# Altium schematic sheet Schematic.SchDoc
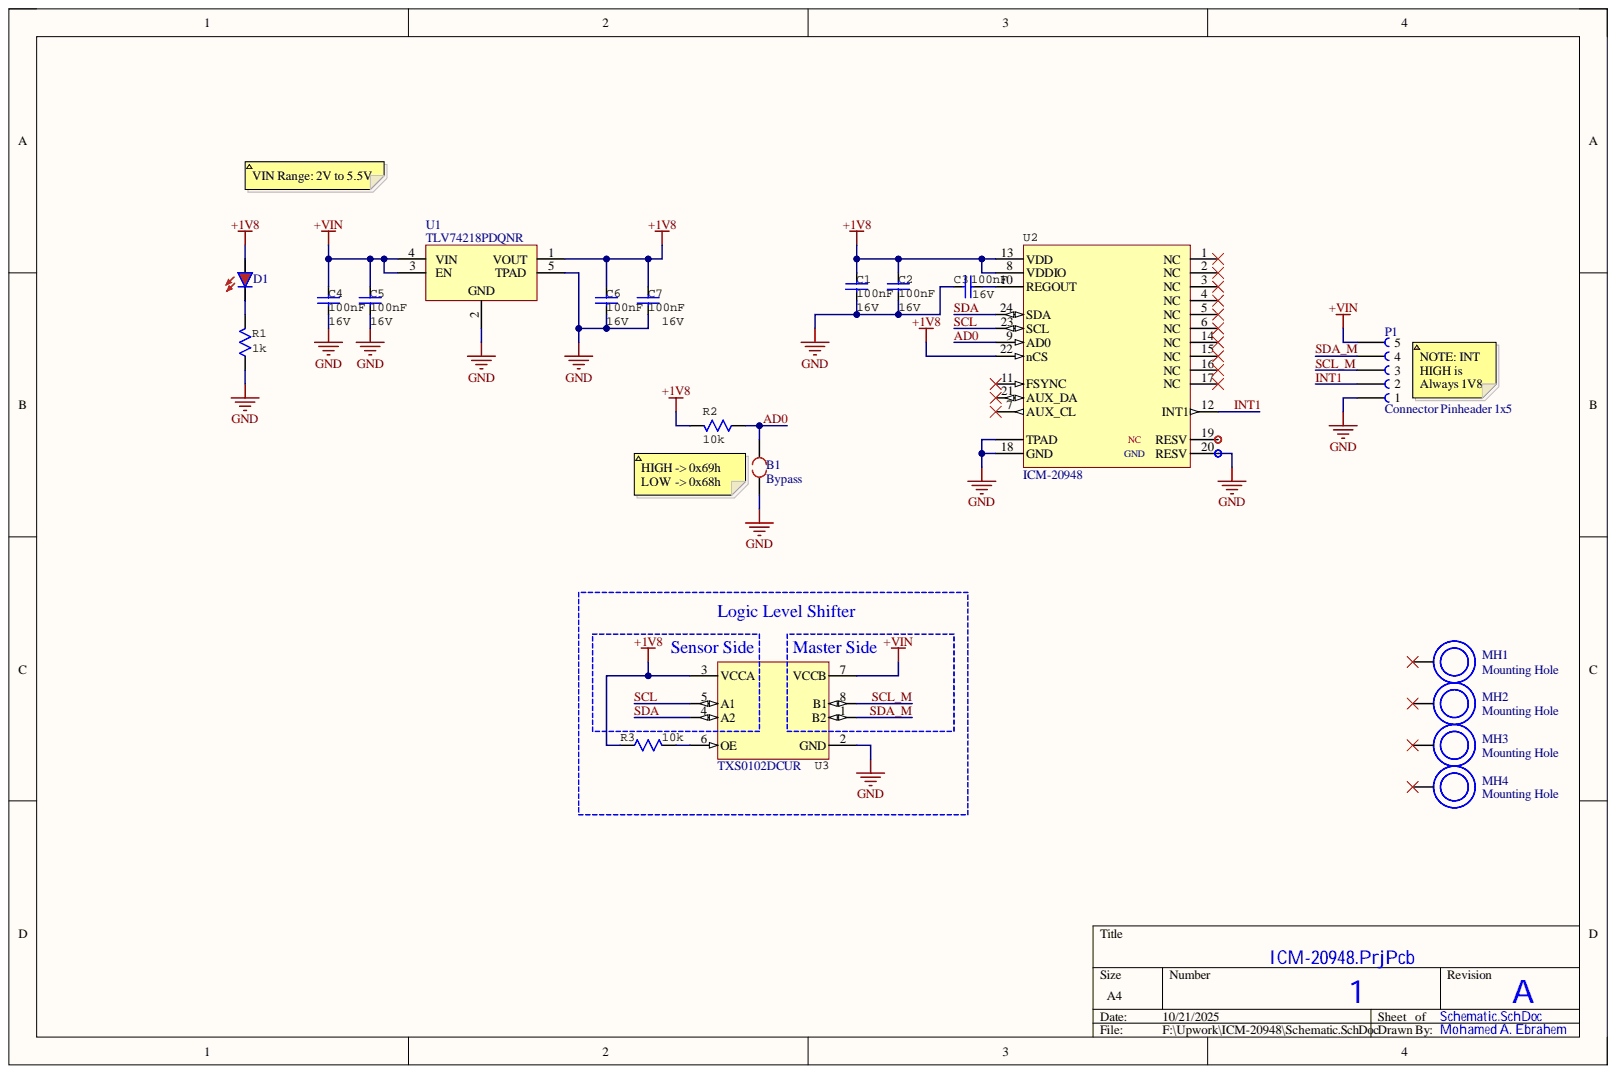
[diagram p0: Schematic.SchDoc]

## Parts
B1  Bypass  [Bypass 2P]  (no BOM)
C1  value 100nF  Voltage: 16V  [CAP Ceramic SMD 0402]
C2  value 100nF  Voltage: 16V  [CAP Ceramic SMD 0402]
C3  value 100nF  Voltage: 16V  [CAP Ceramic SMD 0402]
C4  value 100nF  Voltage: 16V  [CAP Ceramic SMD 0402]
C5  value 100nF  Voltage: 16V  [CAP Ceramic SMD 0402]
C6  value 100nF  Voltage: 16V  [CAP Ceramic SMD 0402]
C7  value 100nF  Voltage: 16V  [CAP Ceramic SMD 0402]
D1  Red  [LED SMD 0603R]  (value not drawn)
MH1  Mounting Hole  [MH 2mm]  (no BOM)
MH2  Mounting Hole  [MH 2mm]  (no BOM)
MH3  Mounting Hole  [MH 2mm]  (no BOM)
MH4  Mounting Hole  [MH 2mm]  (no BOM)
P1  Connector Pinheader 1x5  [Header 2.54X5]  (no BOM)
R1  1k  [RES SMD 0402]
R2  10k  [RES SMD 0402]
R3  10k  [RES SMD 0402]
U1  TLV74218PDQNR  [WSON]
U2  ICM-20948  [QFN127P600-8N]
U3  TXS0102DCUR  [SOP127P600-8N]

## Nets
+1V8: C1.1 C2.1 C6.1 C7.1 D1.1 R2.2 R3.1 U1.1(VOUT) U2.8(VDDIO) U2.13(VDD) U2.22(nCS) U3.3(VCCA)
+VIN: C4.1 C5.1 P1.5 U1.3(EN) U1.4(VIN) U3.7(VCCB)
GND: B1.1 C1.2 C2.2 C3.2 C4.2 C5.2 C6.2 C7.2 P1.1 R1.1 U1.2 U1.5(TPAD) U2.18(GND) U2.20(RESV) U2.25(TPAD) U3.2(GND)
AD0: B1.2 R2.1 U2.9(AD0)
INT1: P1.2 U2.12(INT1)
SCL: U2.23(SCL) U3.5(A1)
SCL_M: P1.3 U3.8(B1)
SDA: U2.24(SDA) U3.4(A2)
SDA_M: P1.4 U3.1(B2)
NetC3_1: C3.1 U2.10(REGOUT)
NetD1_2: D1.2 R1.2
NetR3_2: R3.2 U3.6(OE)

Not connected: MH1 MH; MH2 MH; MH3 MH; MH4 MH; U2 1(NC) 2(NC) 3(NC) 4(NC) 5(NC) 6(NC) 7(AUX_CL) 11(FSYNC) 14(NC) 15(NC) 16(NC) 17(NC) 19(RESV) 21(AUX_DA)

## Library data of the parts
C1-C7 = CAP 0402 100nF 16V X7R 10%: 100nF 16V X7R ±10% 0402 Multilayer Ceramic Capacitors MLCC - SMD/SMT ROHS; Manufacturer: Samsung Electro-Mechanics; Manufacturer Part Number: CL05B104KO5NNNC; Component Kind: Standard; Library Name: Symbols.SchLib; Supplier: LCSC; Supplier Part Number: C1525; Temperature Characteristics Code: X7R
D1 = LED Red 0603: -40℃~+85℃ 120° 2.4V 20mA 300mcd 40mW 615nm~630nm 645nm Red Water Clear 0603 LED Indication - Discrete ROHS; Manufacturer: Hubei KENTO Elec; Manufacturer Part Number: KT-0603R; Component Kind: Standard; Library Name: Symbols.SchLib; Supplier: LCSC; Supplier Part Number: C2286
P1 = Connector Pinheader 1x5: Header_5x1; Library Name: Symbols.SchLib
R1 = RES 0402 1k 1%: -55℃~+155℃ 1kΩ 50V 62.5mW Thick Film Resistor ±1% ±100ppm/℃ 0402 Chip Resistor - Surface Mount ROHS; Manufacturer: UNI-ROYAL(Uniroyal Elec); Manufacturer Part Number: 0402WGF1001TCE; Component Kind: Standard; Library Name: Symbols.SchLib; Supplier: LCSC; Supplier Part Number: C11702; Value: 1k
R2 R3 = RES 0402 10k 1%: -55℃~+155℃ 10kΩ 50V 62.5mW Thick Film Resistor ±1% ±100ppm/℃ 0402 Chip Resistor - Surface Mount ROHS; Manufacturer: UNI-ROYAL(Uniroyal Elec); Manufacturer Part Number: 0402WGF1002TCE; Component Kind: Standard; Library Name: Symbols.SchLib; Supplier: LCSC; Supplier Part Number: C25744; Value: 10k
U1 = TLV74218PDQNR: Positive X2SON-4-EP(1x1) Voltage Regulators - Linear, Low Drop Out (LDO) Regulators ROHS; Manufacturer: TI; Component Kind: Standard; Library Name: Symbols.SchLib; Manufacturer Part Number: TLV74218PDQNR; Supplier: LCSC; Supplier Part Number: C485140
U2 = ICM-20948: Accelerometer Gyroscope QFN-24(3x3) IMUs (Inertial Measurement Units) ROHS; Manufacturer: TDK InvenSense; Component Kind: Standard; Library Name: Symbols.SchLib; Manufacturer Part Number: ICM-20948; Supplier: LCSC; Supplier Part Number: C726001
U3 = TXS0102DCUR: 24Mbps VSSOP-8 Translators, Level Shifters ROHS; Manufacturer: TI; Component Kind: Standard; Library Name: Symbols.SchLib; Manufacturer Part Number: TXS0102DCUR; Supplier: LCSC; Supplier Part Number: C53434
B1 = Bypass: Library Name: Symbols.SchLib
MH1-MH4 = Mounting Hole: Library Name: Symbols.SchLib
